# Altium schematic sheet motor_cpu.SchDoc
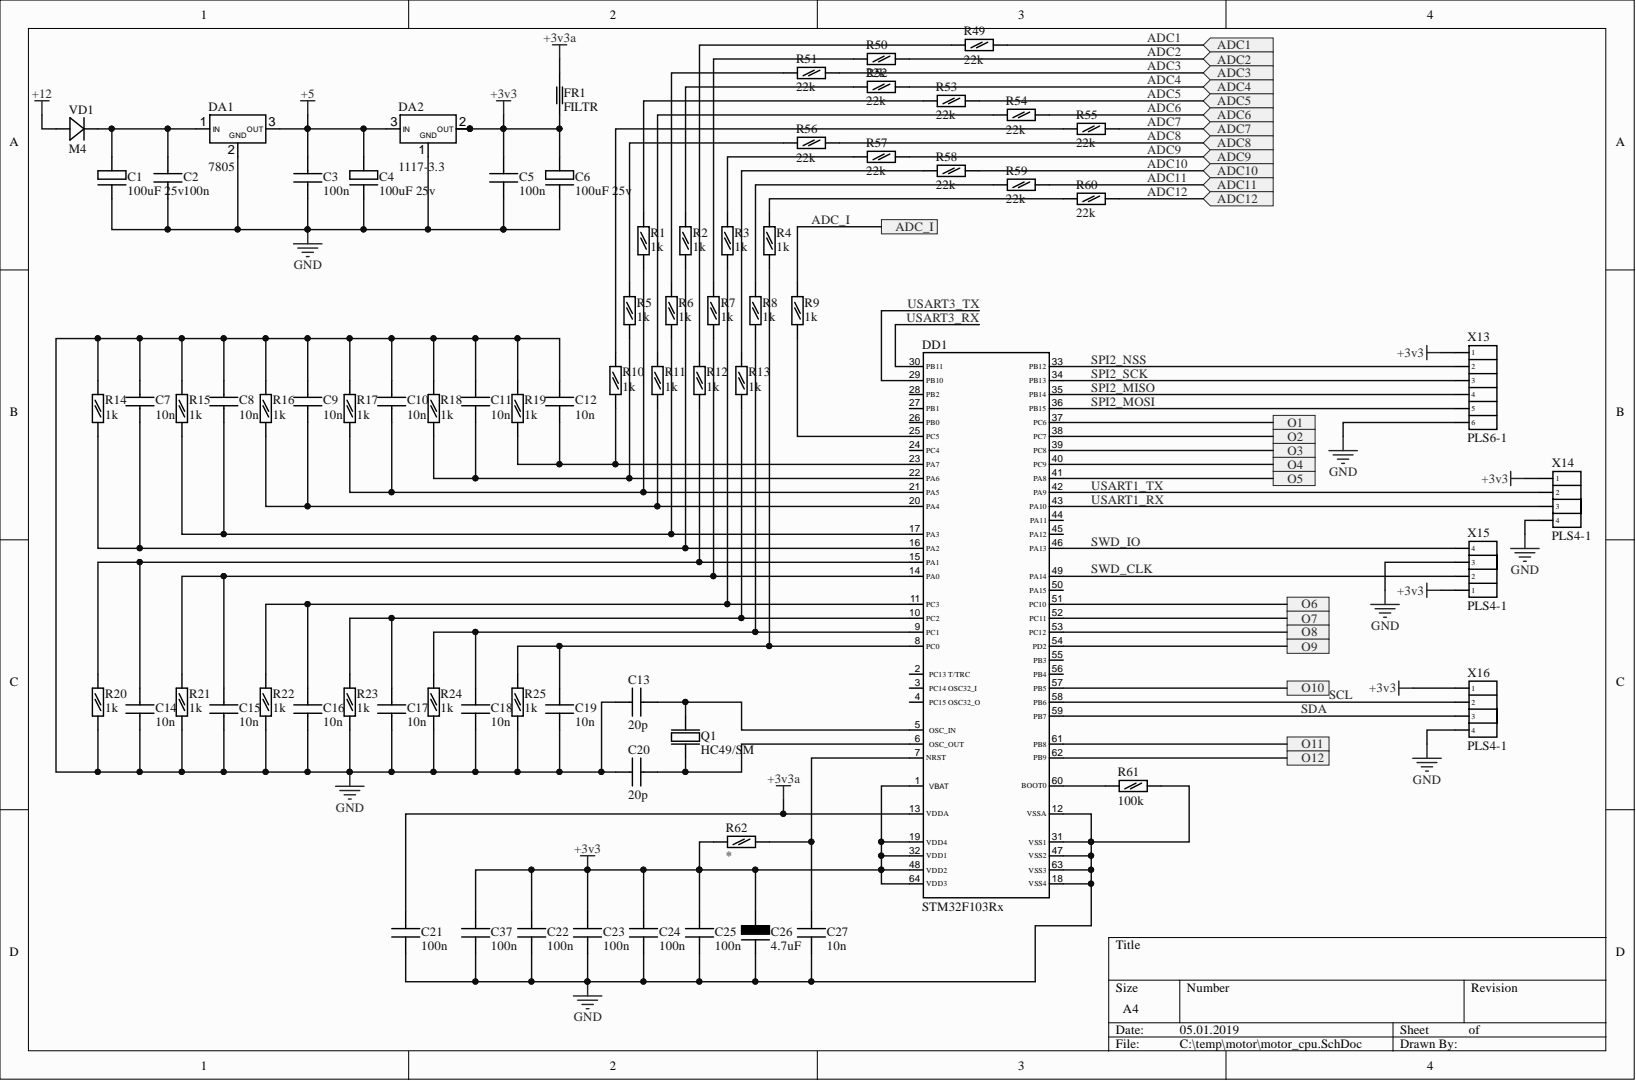
[diagram p0: motor_cpu.SchDoc]

## Parts
C1  100uF 25v  [EL_CAP_5]
C2  100n  [C0805]
C3  100n  [C0805]
C4  100uF 25v  [EL_CAP_5]
C5  100n  [C0805]
C6  100uF 25v  [EL_CAP_5]
C7  10n  [C0805]
C8  10n  [C0805]
C9  10n  [C0805]
C10  10n  [C0805]
C11  10n  [C0805]
C12  10n  [C0805]
C13  20p  [C0805]
C14  10n  [C0805]
C15  10n  [C0805]
C16  10n  [C0805]
C17  10n  [C0805]
C18  10n  [C0805]
C19  10n  [C0805]
C20  20p  [C0805]
C21  100n  [C0805]
C22  100n  [C0805]
C23  100n  [C0805]
C24  100n  [C0805]
C25  100n  [C0805]
C26  4.7uF  [T_CASE_A]
C27  10n  [C0805]
C37  100n  [C0805]
DA1  7805  [TO220]
DA2  1117-3.3  [SOT223]
DD1  STM32F103Rx  [LQFP64]
FR1  FILTR  [R0805]
Q1  HC49/SM  [HC49S]
R1  1k  [R0805]
R2  1k  [R0805]
R3  1k  [R0805]
R4  1k  [R0805]
R5  1k  [R0805]
R6  1k  [R0805]
R7  1k  [R0805]
R8  1k  [R0805]
R9  1k  [R0805]
R10  1k  [R0805]
R11  1k  [R0805]
R12  1k  [R0805]
R13  1k  [R0805]
R14  1k  [R0805]
R15  1k  [R0805]
R16  1k  [R0805]
R17  1k  [R0805]
R18  1k  [R0805]
R19  1k  [R0805]
R20  1k  [R0805]
R21  1k  [R0805]
R22  1k  [R0805]
R23  1k  [R0805]
R24  1k  [R0805]
R25  1k  [R0805]
R49  22k  [R0805]
R50  22k  [R0805]
R51  22k  [R0805]
R52  22k  [R0805]
R53  22k  [R0805]
R54  22k  [R0805]
R55  22k  [R0805]
R56  22k  [R0805]
R57  22k  [R0805]
R58  22k  [R0805]
R59  22k  [R0805]
R60  22k  [R0805]
R61  100k  [R0805]
R62  (no value)  [R0805]
VD1  M4  [SOD123]
X13  PLS6-1  [PLS6-1]
X14  PLS4-1  [PLS4-1]
X15  PLS4-1  [PLS4-1]
X16  PLS4-1  [PLS4-1]

## Ports
ADC1, ADC2, ADC3, ADC4, ADC5, ADC6, ADC7, ADC8, ADC9, ADC10, ADC11, ADC12, ADC_I, O1, O2, O3, O4, O5, O6, O7, O8, O9, O10, O11, O12

## Nets
+3v3: C5.2 C6.1 C22.2 C23.2 C24.2 C25.2 C26.1 C37.2 DA2.2(OUT) DA2.4 DD1.1(VBAT) DD1.19(VDD4) DD1.32(VDD1) DD1.48(VDD2) DD1.64(VDD3) FR1.1 R62.1 X13.1 X14.1 X15.1 X16.1
+3v3a: C21.2 DD1.13(VDDA) FR1.2
+5: C3.2 C4.1 DA1.3 DA2.3(IN)
+12: VD1.2
GND: C1.2 C2.1 C3.1 C4.2 C5.1 C6.2 C7.1 C8.1 C9.1 C10.1 C11.1 C12.1 C13.2 C14.2 C15.2 C16.2 C17.2 C18.2 C19.2 C20.2 C21.1 C22.1 C23.1 C24.1 C25.1 C26.2 C27.1 C37.1 DA1.2 DA2.1 DD1.12(VSSA) DD1.18(VSS4) DD1.31(VSS1) DD1.47(VSS2) DD1.63(VSS3) R14.1 R15.1 R16.1 R17.1 R18.1 R19.1 R20.2 R21.2 R22.2 R23.2 R24.2 R25.2 R61.2 X13.6 X14.4 X15.3 X16.4
ADC1: R49.2 ; port ADC1
ADC2: R50.2 ; port ADC2
ADC3: R51.2 ; port ADC3
ADC4: R52.2 ; port ADC4
ADC5: R53.2 ; port ADC5
ADC6: R54.2 ; port ADC6
ADC7: R55.2 ; port ADC7
ADC8: R56.2 ; port ADC8
ADC9: R57.2 ; port ADC9
ADC10: R58.2 ; port ADC10
ADC11: R59.2 ; port ADC11
ADC12: R60.2 ; port ADC12
ADC_I: R9.1 ; port ADC_I
O1: DD1.37(PC6) ; port O1
O2: DD1.38(PC7) ; port O2
O3: DD1.39(PC8) ; port O3
O4: DD1.40(PC9) ; port O4
O5: DD1.41(PA8) ; port O5
O6: DD1.51(PC10) ; port O6
O7: DD1.52(PC11) ; port O7
O8: DD1.53(PC12) ; port O8
O9: DD1.54(PD2) ; port O9
O10: DD1.57(PB5) ; port O10
O11: DD1.61(PB8) ; port O11
O12: DD1.62(PB9) ; port O12
SCL: DD1.58(PB6) X16.2
SDA: DD1.59(PB7) X16.3
SPI2_MISO: DD1.35(PB14) X13.4
SPI2_MOSI: DD1.36(PB15) X13.5
SPI2_NSS: DD1.33(PB12) X13.2
SPI2_SCK: DD1.34(PB13) X13.3
SWD_CLK: DD1.49(PA14) X15.2
SWD_IO: DD1.46(PA13) X15.4
USART1_RX: DD1.43(PA10) X14.3
USART1_TX: DD1.42(PA9) X14.2
USART3_RX: DD1.30(PB11)
USART3_TX: DD1.29(PB10)
NetC1_1: C1.1 C2.2 DA1.1 VD1.1
NetC7_2: C7.2 DD1.16(PA2) R2.2 R14.2
NetC8_2: C8.2 DD1.17(PA3) R6.2 R15.2
NetC9_2: C9.2 DD1.20(PA4) R11.2 R16.2
NetC10_2: C10.2 DD1.21(PA5) R1.2 R17.2
NetC11_2: C11.2 DD1.22(PA6) R5.2 R18.2
NetC12_2: C12.2 DD1.23(PA7) R10.2 R19.2
NetC13_1: C13.1 DD1.5(OSC_IN) Q1.1
NetC14_1: C14.1 DD1.15(PA1) R12.2 R20.1
NetC15_1: C15.1 DD1.14(PA0) R7.2 R21.1
NetC16_1: C16.1 DD1.11(PC3) R3.2 R22.1
NetC17_1: C17.1 DD1.10(PC2) R13.2 R23.1
NetC18_1: C18.1 DD1.9(PC1) R8.2 R24.1
NetC19_1: C19.1 DD1.8(PC0) R4.2 R25.1
NetC20_1: C20.1 DD1.6(OSC_OUT) Q1.2
NetC27_2: C27.2 DD1.7(NRST) R62.2
NetDD1_25: DD1.25(PC5) R9.2
NetDD1_60: DD1.60(BOOT0) R61.1
NetR1_1: R1.1 R53.1
NetR2_1: R2.1 R52.1
NetR3_1: R3.1 R57.1
NetR4_1: R4.1 R60.1
NetR5_1: R5.1 R56.1
NetR6_1: R6.1 R51.1
NetR7_1: R7.1 R50.1
NetR8_1: R8.1 R59.1
NetR10_1: R10.1 R55.1
NetR11_1: R11.1 R54.1
NetR12_1: R12.1 R49.1
NetR13_1: R13.1 R58.1

Not connected: DD1 2(PC13_T/TRC) 3(PC14_OSC32_I) 4(PC15_OSC32_O) 24(PC4) 26(PB0) 27(PB1) 28(PB2) 44(PA11) 45(PA12) 50(PA15) 55(PB3) 56(PB4)

## Library data of the parts
C1 C4 C6 = CAP_EL: Component Kind: Standard; Library Name: new_Library.IntLib; value: 100uF 25v
C2 C3 C5 C7-C25 C27 C37 = CAP_CER: Component Kind: Standard; Library Name: new_Library.IntLib
  C2 C3 C5 C21-C25 C37: value: 100n
  C7-C12 C14-C19 C27: value: 10n
  C13 C20: value: 20p
C26 = CAP_TAN: Component Kind: Standard; Library Name: new_Library.IntLib; value: 4.7uF
DA1 = 78XX: Component Kind: Standard; Library Name: new_Library.IntLib; value: 7805
DA2 = 1117_XX: Component Kind: Standard; Library Name: new_Library.IntLib; value: 1117-3.3
Q1 = HC49S: Component Kind: Standard; Library Name: new_Library.IntLib; value: HC49/SM
R1-R25 R49-R62 = RES_0805: Component Kind: Standard; Library Name: new_Library.IntLib
  R1-R25: value: 1k
  R49-R60: value: 22k
  R61: value: 100k
VD1 = DIODE_SM: Component Kind: Standard; Library Name: new_Library.IntLib; value: M4
DD1 = STM32F103Rx: Component Kind: Standard; Library Name: new_Library.IntLib
FR1 = FILTR: Component Kind: Standard; Library Name: new_Library.IntLib
X13 = PLS6-1: Component Kind: Standard; Library Name: new_Library.IntLib
X14-X16 = PLS4-1: Component Kind: Standard; Library Name: new_Library.IntLib
